# Altium schematic sheet Peripherals.SchDoc
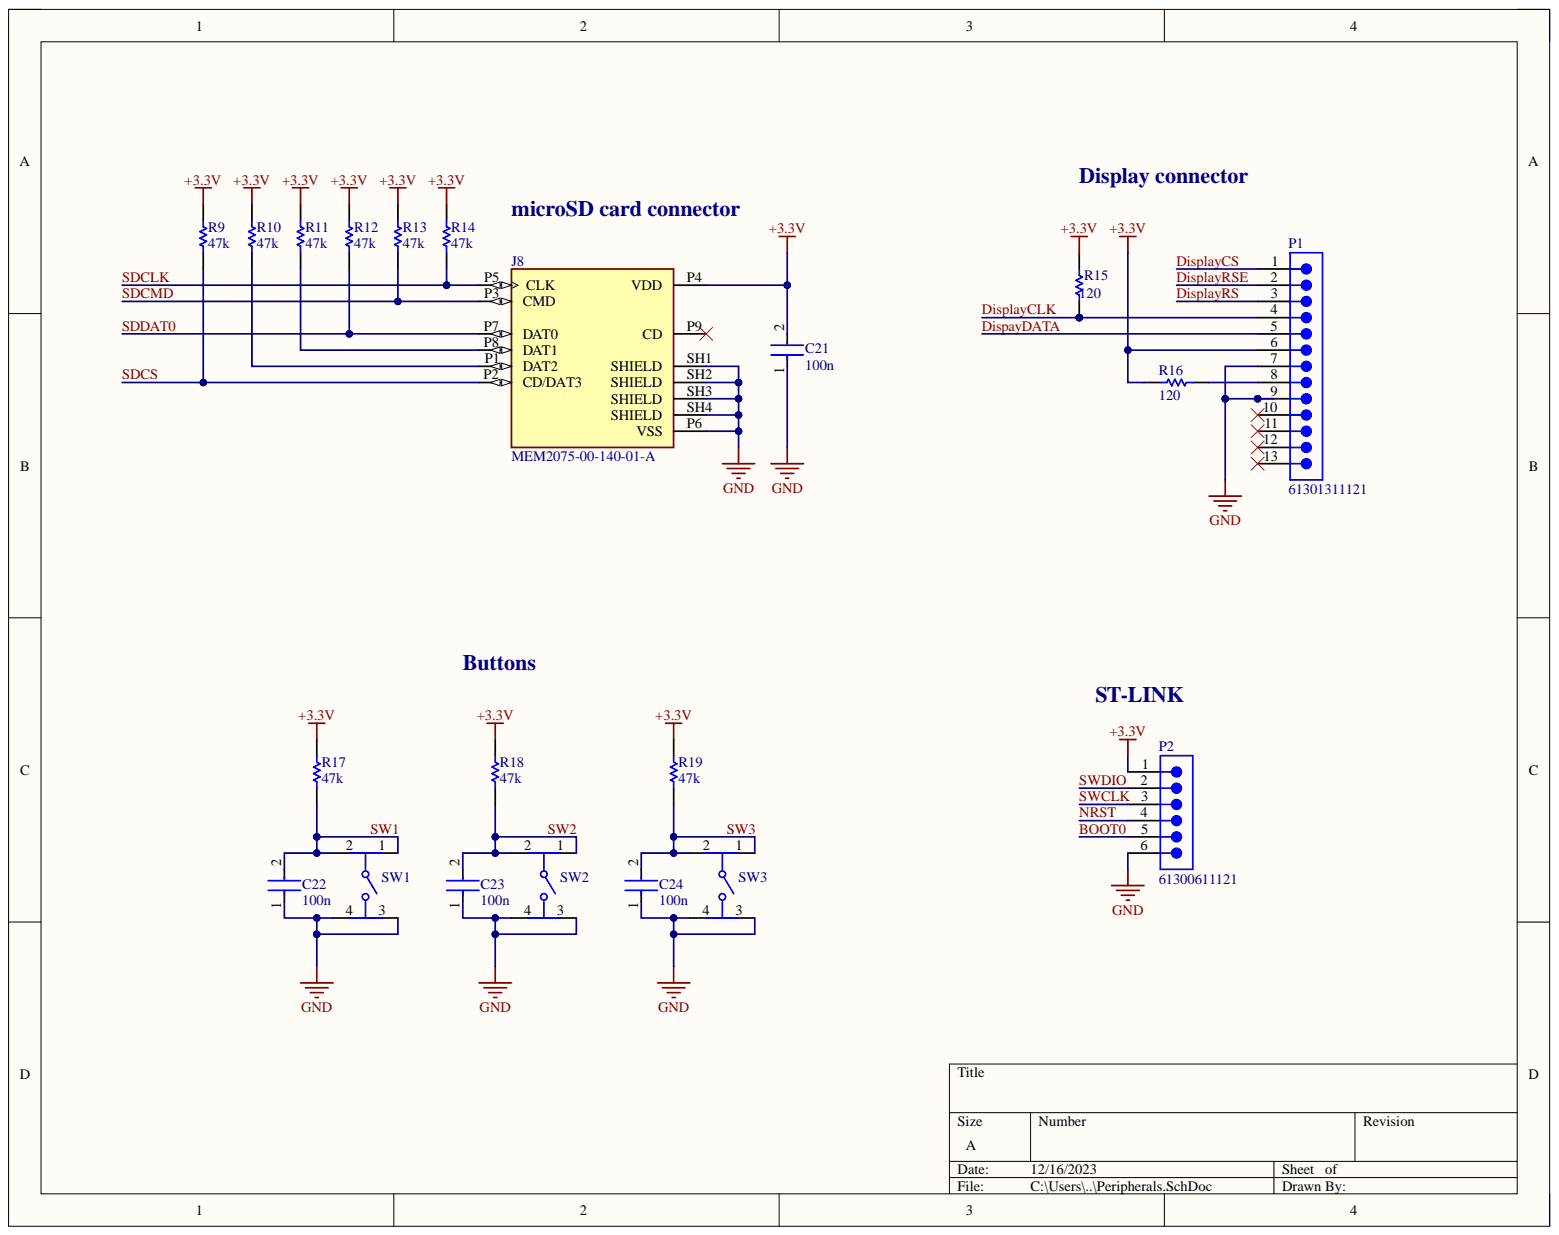
[diagram p0: Peripherals.SchDoc]

## Parts
C21  100n  [FP-C0603C-CF-MFG]
C22  100n  [FP-C0603C-CF-MFG]
C23  100n  [FP-C0603C-CF-MFG]
C24  100n  [FP-C0603C-CF-MFG]
J8  MEM2075-00-140-01-A  [GCT_MEM2075-00-140-01-A]
P1  61301311121  [61301311121]
P2  61300611121  [61300611121]
R9  47k  [RESC2013X60X35ML10T20]
R10  47k  [RESC2013X60X35ML10T20]
R11  47k  [RESC2013X60X35ML10T20]
R12  47k  [RESC2013X60X35ML10T20]
R13  47k  [RESC2013X60X35ML10T20]
R14  47k  [RESC2013X60X35ML10T20]
R15  120  [RESC2013X60X35ML10T20]
R16  120  [RESC2013X60X35ML10T20]
R17  47k  [RESC2013X60X35ML10T20]
R18  47k  [RESC2013X60X35ML10T20]
R19  47k  [RESC2013X60X35ML10T20]
SW1  1-1825910-0  [FP-1-1825910-0-MFG]  (value not drawn)
SW2  1-1825910-0  [FP-1-1825910-0-MFG]  (value not drawn)
SW3  1-1825910-0  [FP-1-1825910-0-MFG]  (value not drawn)

## Nets
+3.3V: C21.2 J8.P4(VDD) P1.6 P2.1 R9.2 R10.2 R11.2 R12.2 R13.2 R14.2 R15.1 R16.2 R17.2 R18.2 R19.2
GND: C21.1 C22.1 C23.1 C24.1 J8.P6(VSS) J8.SH1(SHIELD) J8.SH2(SHIELD) J8.SH3(SHIELD) J8.SH4(SHIELD) P1.7 P1.9 P2.6 SW1.3 SW1.4 SW2.3 SW2.4 SW3.3 SW3.4
BOOT0: P2.5
DispayDATA: P1.5
DisplayCLK: P1.4 R15.2
DisplayCS: P1.1
DisplayRS: P1.3
DisplayRSE: P1.2
NRST: P2.4
SDCLK: J8.P5(CLK) R14.1
SDCMD: J8.P3(CMD) R13.1
SDCS: J8.P2(CD/DAT3) R9.1
SDDAT0: J8.P7(DAT0) R12.1
SW1: C22.2 R17.1 SW1.1 SW1.2
SW2: C23.2 R18.1 SW2.1 SW2.2
SW3: C24.2 R19.1 SW3.1 SW3.2
SWCLK: P2.3
SWDIO: P2.2
NetJ8_P1: J8.P1(DAT2) R10.1
NetJ8_P8: J8.P8(DAT1) R11.1
NetP1_8: P1.8 R16.1

Not connected: J8 P9(CD); P1 10 11 12 13

## Library data of the parts
C21-C24 = CMP-2006-03061-2: CAP CER 0.1UF 50V X7R 0603; Manufacturer: KEMET; Manufacturer Part Number: C0603C104K5RACTU; Automotive Grade: Grade 1; Capacitance: 0.10 μF; Material: Ceramic; Temperature Coefficient: 30ppm/°C; Tolerance: 0.1; Value: 0.10 μF; Automotive: Yes; Category: Cap; Component Kind: Standard; ComponentLink2Description: Datasheet; ComponentLink2URL: https://api.kemet.com/component-edge/download/datasheet/C0603C100J5GACAUTO.pdf; Device Class L1: Passive Components; Device Class L2: Capacitors; Device Class L3: Ceramic Capacitors; Digikey Description: CAP CER 0.1UF 50V X7R 0603; Height: 0.87mm; Lead Free: Yes; Library Name: Altium Content Vault; Octopart: https://octopart.com/c0603c104k5ractu-kemet-139244; Package: C0603C-CF; RoHS: TRUE; Temperature: -55°C to +125°C; Temperature Characteristic: X7R; Temperature Range High: +125°C; Temperature Range Low: -55°C; Voltage Rating: 50 V
J8 = MEM2075-00-140-01-A: Micro SD Memory Card Connector, 1.4mm Profile SMT, Push-Push, with Normally open Switch; Availability: Unavailable; Component Kind: Standard; Library Name: MEM2075-00-140-01-A.SchLib; MP: MEM2075-00-140-01-A; Package: None; Price: None
P1 = CMP-1502-01089-2: NexarPartId: 32855541; Connector Type: Male Pin; Contact Resistance: 20mΩ; Current Rating: 3A; Gender: Male; Number of Contacts: 13; Operating Supply Voltage: 250V; Pitch: 2.54mm; Color: Black; Component Kind: Standard; Contact Material: Copper; Contact Mating Length: 5.9944mm; Contact Plating: Gold; Lead Free: Lead Free; Lead Pitch: 2.54mm; Library Name: Altium Content Vault; Mount: Through Hole; Number of Pins: 13; Number of Positions: 13; Number of Rows: 1; Orientation: Vertical; Packaging: Bulk; REACH SVHC: Unknown; RoHS: Compliant; Termination: Solder
P2 = CMP-1502-01070-2: NexarPartId: 20084590; Connector Type: Male Pin; Contact Resistance: 20mΩ; Current Rating: 3A; Gender: Male; Number of Contacts: 6; Operating Supply Voltage: 250V; Pitch: 2.54mm; Color: Black; Component Kind: Standard; Contact Gender: Male; Contact Material: Copper; Contact Mating Length: 5.9944mm; Contact Plating: Gold; Height: 8.89mm; Housing Material: PBT; Lead Free: Lead Free; Lead Pitch: 2.54mm; Library Name: Altium Content Vault; Max Operating Temperature: 105°C; Min Operating Temperature: -40°C; Mount: Through Hole; Number of Pins: 6; Number of Positions: 6; Number of Rows: 1; Orientation: Vertical; Packaging: Bulk; REACH SVHC: Unknown; RoHS: Compliant; Termination: Through Hole; Voltage Rating: 250V
R9-R19 = CMP-2100-03673-1: Chip Resistor, 0 Ohm, Jumper, +/- 5%, 0.125 W, -55 to 155 degC, 0805 (2012 Metric), RoHS, Tape and Reel; Manufacturer: Yageo; Power Rating: 125mW; Resistance: 0mOhm; Temperature Coefficient: 200ppm/degreesC; Voltage Rating: 150V; Case Code (Imperial): 0805; Case Code (Metric): 2012; Case/Package: 0805; Component Kind: Standard; Composition: ThickFilm; Contact Plating: Tin; Datasheet URL: http://www.yageo.com/NewPortal/yageodocoutput?fileName=/pdf/R-Chip/PYu-RC_Group_51_RoHS_L_5.pdf; Datasheet Version: Rev. 5, 10/2016; Depth: 1.25mm; Height: 0.5mm; Lead Free: LeadFree; Length: 2mm; Library Name: Altium Content Vault; Manufacturer URL: http://www.yageo.com/; Max Operating Temperature: 155degC; Military Standard: Not; Min Operating Temperature: -55degC; Number of Pins: 2; Package Description: 2-Pin Surface Mounted Device, Body 2 x 1.25 mm; Package Reference: 0805; Packaging: TapeandReel; Radiation Hardening: No; REACH SVHC: Unknown; RoHSCompliant: true; Termination: SMD/SMT; Tolerance: 5%; Voltage Rating (DC): 150V; Width: 1.25mm
SW1-SW3 = CMP-03407-000018-1: SWITCH TACTILE SPST-NO 0.05A 24V; Actuator Color: Blue; Actuator Length: 3.4mm; Actuator Material: Nylon; Actuator Type: Standard; Contact Current Rating: 50mA; Contact Resistance: 100mR; Electrical Life: 100000Cycles; Illumination Type: Non-Illuminated; Operating Force: 1.56N; Switch Function: Off-Mom; Switch Travel: 0.25mm; Throw Configuration: SPST; Component Kind: Standard; Contact Material: Brass; Contact Plating: Silver; Depth: 5.99mm; Length: 5.99mm; Library Name: Altium Content Vault; Max Current Rating: 50mA; Max Operating Temperature: 85°C; Max Voltage Rating (DC): 24V; Mechanical Life: 100000Cycles; Min Operating Temperature: -35°C; Mount: Through Hole; Orientation: Straight; Package Quantity: 750; Packaging: Loose Piece; Radiation Hardening: No; RoHS Compliant: Yes; Series: FSMJ, Alcoswitch; Termination: PC Pins; Type: Switch; Voltage Rating (DC): 24V
